# Altium schematic sheet Sheet1.SchDoc
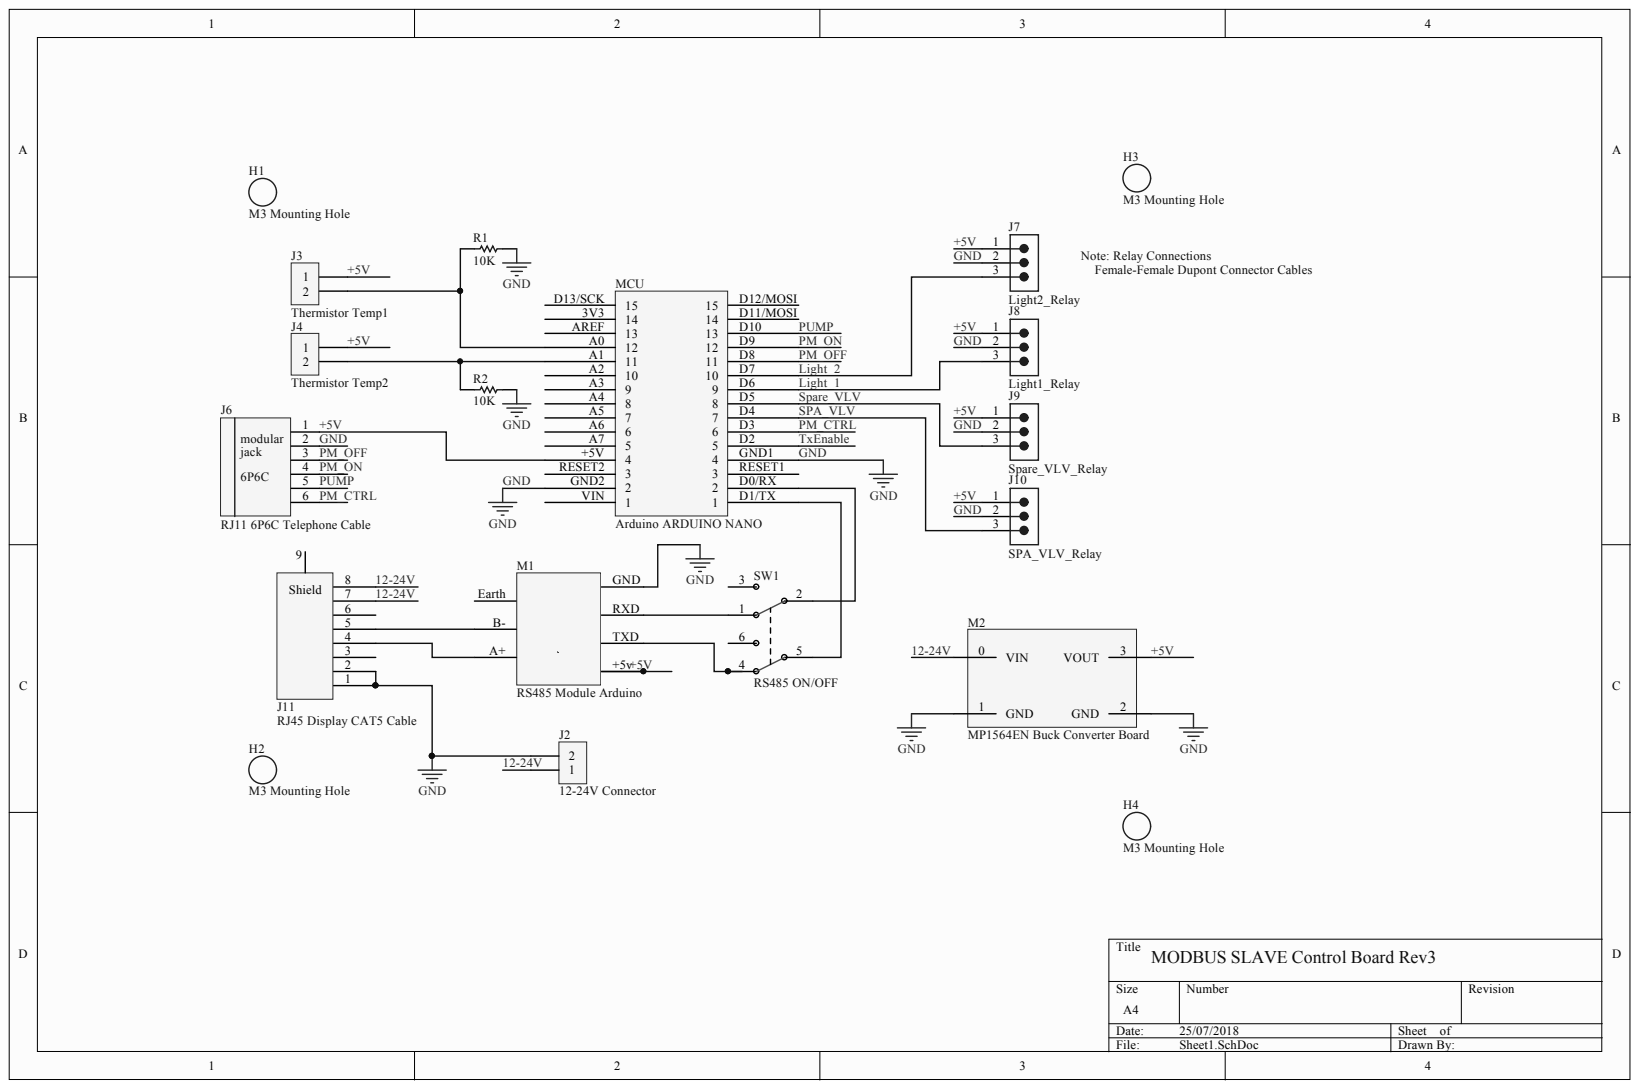
[diagram p0: Sheet1.SchDoc]

## Parts
H1  M3 Mounting Hole  [PCB-sb9r54v1ukudlsmquqqd-1]
H2  M3 Mounting Hole  [PCB-sb9r54v1ukudlsmquqqd-1]
H3  M3 Mounting Hole  [PCB-sb9r54v1ukudlsmquqqd-1]
H4  M3 Mounting Hole  [PCB-sb9r54v1ukudlsmquqqd-1]
J2  12-24V Connector  [PCB-aknpg75yzj2hq04tmkjt-1]
J3  Thermistor Temp1  [PCB-aknpg75yzj2hq04tmkjt-1]
J4  Thermistor Temp2  [PCB-aknpg75yzj2hq04tmkjt-1]
J6  RJ11 6P6C Telephone Cable  [PCB-617631-1]
J7  Light2_Relay  [PCB-0acd9ij3o7hs0jkl1v86-1]
J8  Light1_Relay  [PCB-0acd9ij3o7hs0jkl1v86-1]
J9  Spare_VLV_Relay  [PCB-0acd9ij3o7hs0jkl1v86-1]
J10  SPA_VLV_Relay  [PCB-0acd9ij3o7hs0jkl1v86-1]
J11  RJ45 Display CAT5 Cable  [PCB-lgdn83qdcnhdjbf49e3k-1]
M1  RS485 Module Arduino  [PCB-fd05ettsbss648nlesx9-1]
M2  MP1564EN Buck Converter Board  [PCB-li055xbo9p6zs65dyiu8-1]
MCU  Arduino ARDUINO NANO  [PCBComponent_1 - duplicate]
R1  10K  [PCB-syetp4j1w4ffj1r241qw-1]
R2  10K  [PCB-syetp4j1w4ffj1r241qw-1]
SW1  RS485 ON/OFF  [PCB-4o0hqz6pdnge3ng8ta2d-1]

## Nets
12-24V: J2.1 J11.7 J11.8 M2.0(VIN)
+5V: J3.1 J4.1 J6.1 J7.1 J8.1 J9.1 J10.1 M1.+5v M2.3(VOUT) MCU.+5V
GND: J2.2 J6.2 J7.2 J8.2 J9.2 J10.2 J11.1 J11.2 M1.GND M2.1(GND) M2.2(GND) MCU.GND1 MCU.GND2 R1.2 R2.2
LIGHT_1: J8.3 MCU.D6
LIGHT_2: J7.3 MCU.D7
PM_CTRL: J6.6 MCU.D3
PM_OFF: J6.3 MCU.D8
PM_ON: J6.4 MCU.D9
PUMP: J6.5 MCU.D10
SPA_VLV: J10.3 MCU.D4
SPARE_VLV: J9.3 MCU.D5
TXENABLE: MCU.D2
NetJ3_2: J3.2 MCU.A0 R1.1
NetJ4_2: J4.2 MCU.A1 R2.1
NetJ11_4: J11.4 M1.A+
NetJ11_5: J11.5 M1.B-
NetM1_Earth: M1.Earth
NetM1_RXD: M1.RXD SW1.1
NetM1_TXD: M1.TXD SW1.4
NetMCU_D0/RX: MCU.D0/RX SW1.2
NetMCU_D1/TX: MCU.D1/TX SW1.5
NetSW1_3: SW1.3
NetSW1_6: SW1.6

Not connected: J11 3 6 9; MCU 3V3 A2 A3 A4 A5 A6 A7 AREF D11/MOSI D12/MOSI D13/SCK RESET1 RESET2 VIN

## Library data of the parts
H1-H4 = CMP-2eajnev4pcr11g3c6yc7-1: M3 Mountig Hole; Component Kind: Standard; CustomComponentID: 2eajnev4pcr11g3c6yc7; Library Name: CommunityVault
J2-J4 = CMP-0680cf8a1162d947-2: Phoenix 1757242 MSTBA 2.5/ 2-G-5.08 Base Strip/Header 4 Kilo-Volt Surge 320 Volt Rated III/2 12 Amp Green Plug-In; Current Rating: 12.0 A; Gender: Male; Lead-Free Status: Lead Free; Mounting Angle: 90.0 °; Number of Contacts: 2; Pin Pitch: 0.00508 m; Voltage Rating (DC): 320.0 V; Color: Green; Component Kind: Standard; Flammability Rating: UL 94 V-0; Housing Color: Green; Housing Material: Plastic; Library Name: CommunityVault; Manufacturer Part Number: 1757242; Mounting Style: PCB, Through Hole, Solder; Number of Positions: 2; Number of Rows: 1; OctopartID: 0680cf8a1162d947; Octopart URL: http://octopart.com/1757242-phoenix+contact-12932; REACH SVHC Compliance: No SVHC; RoHS: Compliant; Size-Height: 0.0086 m; Size-Length: 0.01216 m; Size-Width: 0.00508 m
J6 = CMP-617631-5: Contact Resistance: 0.02 ?; Current Rating: 1.5 A; Gender: Male; Insulation Resistance: 500000000.0 ?; Number of Contacts: 6; Number of Mating Cycles: 500; Color: Black; Component Kind: Standard; Contact Material: Copper; Contact Plating: Gold; Description: RJ11 6P6C Telephone Cable; Flammability Rating: UL 94 V-0; Library Name: CommunityVault; Mounting Style: Through Hole; OctopartID: 186cd1ee2e20c7ab; Octopart Url: https://octopart.com/615006138421-w%C3%BCrth+elektronik-8917802; REACH SVHC Compliance: No SVHC; RoHS: Compliant
J7-J10 = CMP-683aa16207d4b7fd-11: 2.54mm (0.1") Pitch SIL Vertical PC Tail Pin Header, 6.1mm mating pin height, gold (hi-temp mould), 3 contacts; Manufacturer Part Number: M20-9990345; Contact Resistance: 15.0 mΩ; Current Rating: 3.00 A; Gender: Male; Number of Contacts: 3; Number of Mating Cycles: 300; Voltage Rating (AC): 750 V; Component Kind: Standard; Contact Material: Phosphor Bronze; Library Name: CommunityVault; Mounting Style: Through Hole, Vertical; Octopart URL: https://octopart.com/m20-9990345-harwin-5393032; Pin Pitch: 2.54 mm; REACH SVHC Compliance: No SVHC; RoHS: Compliant
J11 = CMP-95985ce492dd4a5f-11: Socket; RJ45; PIN: 8; Cat: 5e; shielded; gold plated; THT; angled 90°; Component Kind: Standard; Library Name: CommunityVault
M1 = CMP-3b2urf91aox2uunr70m7-5: RS485 Module with auto flow control; Component Kind: Standard; CustomComponentID: 3b2urf91aox2uunr70m7; Library Name: CommunityVault
M2 = CMP-21c200n1kdmmc9b8929b-12: Popular low profile breakout board; Component Kind: Standard; CustomComponentID: 21c200n1kdmmc9b8929b; Library Name: CommunityVault
MCU = CMP-1f01d29ed047c0c6-2: Arduino Nano; Component Kind: Standard; Library Name: CommunityVault; Manufacturer Part Number: ARDUINO NANO; OctopartID: 1f01d29ed047c0c6
R1 R2 = CMP-0439cd32ffbbdbfe-1: Resistor; Carbon Composition; Res 270 Ohms; Pwr-Rtg 0.25 W; Tol 5%; Axial; Component Kind: Standard; Library Name: CommunityVault
SW1 = CMP-0b36c2500016d4f1-7: JS Series DPDT On-On PC Pin Through Hole Subminiature Slide Switch; Lead-Free Status: Lead Free; Component Kind: Standard; Library Name: CommunityVault; Mounting Style: PC Board, Through Hole; OctopartID: 0b36c2500016d4f1; Octopart URL: https://octopart.com/js202011cqn-c%26k+components-7089734; Orientation: Vertical; Packaging: Bulk; REACH SVHC Compliance: No SVHC; RoHS: Compliant
